# Altium schematic sheet Ardu_gen_main.SchDoc
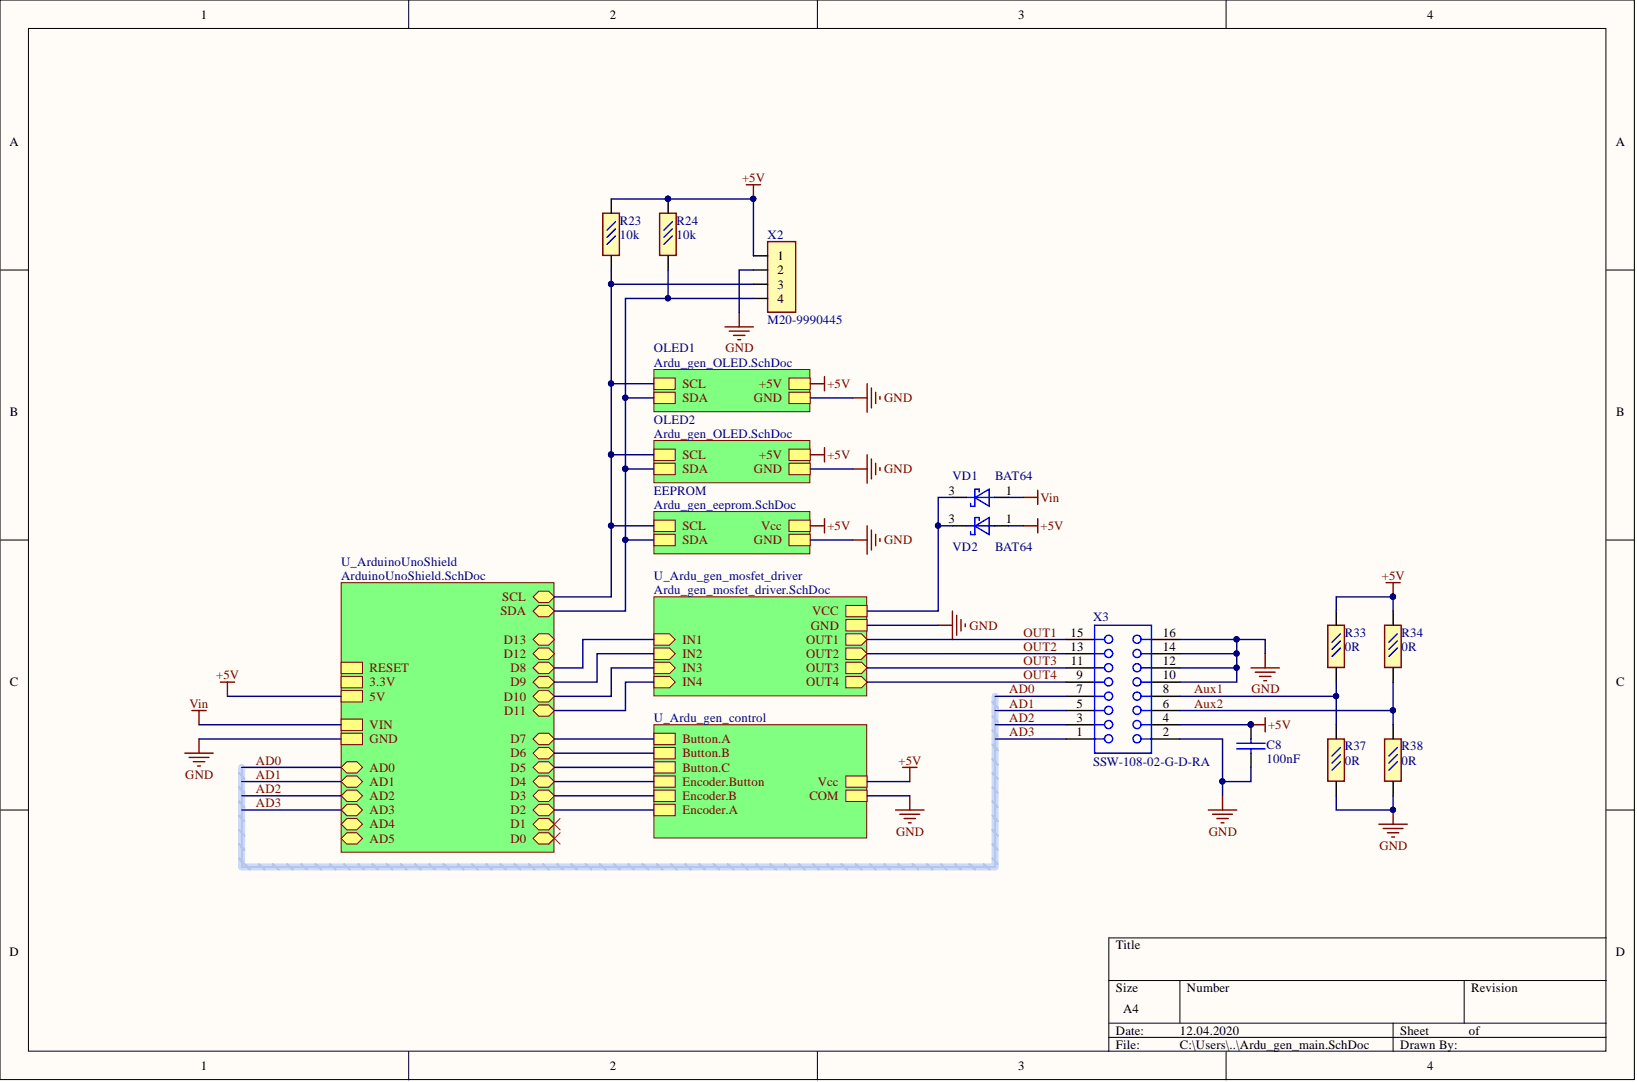
[diagram p0: Ardu_gen_main.SchDoc]

## Parts
C8  100nF  [0603c]
R23  10k  [0603r]
R24  10k  [0603r]
R33  0R  [0603r]
R34  0R  [0603r]
R37  0R  [0603r]
R38  0R  [0603r]
VD1  BAT64  [SOT-23-3]
VD2  BAT64  [SOT-23-3]
X2  M20-9990445  [M20-9990445]
X3  SSW-108-02-G-D-RA  [SMTC-SSW-108-02-X-D-RA]

## Nets
+5V: C8.1 R23.1 R24.1 R33.1 R34.1 VD2.1 X2.1 X3.4
GND: C8.2 R37.2 R38.2 X2.2 X3.2 X3.10 X3.12 X3.14 X3.16
Vin: VD1.1
AD0: X3.7
AD1: X3.5
AD2: X3.3
AD3: X3.1
Aux1: R33.2 R37.1 X3.8
Aux2: R34.2 R38.1 X3.6
OUT1: X3.15
OUT2: X3.13
OUT3: X3.11
OUT4: X3.9
NetR23_2: R23.2 X2.3
NetR24_2: R24.2 X2.4
NetVD1_3: VD1.3 VD2.3
3.3V:
AD4:
AD5:
Button.A:
Button.B:
Button.C:
D0:
D1:
D12:
D13:
Encoder.A:
Encoder.B:
Encoder.Button:
IN1:
IN2:
IN3:
IN4:
N00026:
RESET:

## Library data of the parts
C8 = 100nF 25V 0603: Company: Yageo; ID: B1153B11114; Order Code: CC0603KRX7R8BB104; TSKD: FS-CM_StandDet_Capacitor_CeramicSMD(100nF_25_10%_0603_X7R); Type: Capacitor; Component Kind: Standard; Description: 100nF 25V 0603; Supplier 1: Digi-Key; Supplier Part Number 1: 311-1341-1-ND; Value: 100nF; Library Name: CAPACITOR_dropbox.SchLib
R23 R24 = 10k 0603 5%: Company: Yageo; ID: B112CV1113Y; Order Code: RC0603JR-0710KL; TSKD: FS-CM_StandDet_Resistor_SMD(10K_5%_100_0603); Component Kind: Standard; Description: 10k 0603 5%; Library Name: RESISTORs_Home.SchLib; Supplier 1: Digi-Key; Supplier Part Number 1: 311-10KGRCT-ND; Type: Resistor; Value: 10k
R33 R34 R37 R38 = 0R  0603 5%: 0R 0603  5%; Company: Yageo; Order Code: RC0603JR-070R; Component Kind: Standard; Supplier 1: Digi-Key; Supplier Part Number 1: 311-0.0GRCT-ND; Type: Resistor; Value: 0R; Library Name: RESISTORs_Home.SchLib
VD1 VD2 = BAT64: 40V 250mA 0.57V 5ns 6pF; Company: INFINEON; ID: B115BJ1111F; Order Code: BAT64E6327; TSKD: FS-CM_StandDet_Diode_SMD(BAT64_SOT-23); Type: Diode; Component Kind: Standard; Library Name: DIODE_home.SchLib; Supplier 1: Digi-Key; Supplier Part Number 1: BAT 64 E6327CT-ND
X2 = M20-9990445: 4pin 2.54 vertical; Company: Harwin; Component Kind: Standard; Mounting: TH; Order Code: M20-9990445; Type: Connector; Value: M20-9990445; Library Name: SOEDINITELI_hOME.SchLib
X3 = SSW-108-02-G-D-RA: SQ Post Socket, Through-hole, Right Angle, -55 to 125 degC, 2.54mm Pitch, 16-Pin, Female, RoHS; Manufacturer: Samtec; System: Connector; Component Kind: Standard; ComponentLink1Description: Manufacturer URL; ComponentLink1URL: http://www.samtec.com; ComponentLink2Description: Datasheet; ComponentLink2URL: http://www.samtec.com/documents/webfiles/pdf/SSW_TH.PDF; ComponentLink3Description: Package Specification; ComponentLink3URL: http://www.samtec.com/documents/webfiles/cpdf/SSW-1XX-XX-XXX-X-XX-XXX-XX-MKT.pdf; DatasheetVersion: F-214; Library Name: Samtec_SSW_108_02_G_D_RA.SchLib; Mounting Technology: Through-hole; Orientation: Right Angle; PackageDescription: Assembly, Socket Strip .016 X .031 Tail; PackageReference: SSW-108-02-X-D-RA; PackageVersion: CA, 6/1987; PartNumber: SSW-108-02-G-D-RA; Pitch: 2.54 mm; RoHS: True; Temperature Range: -55 to 125 degC
